# Altium schematic sheet Power.SchDoc
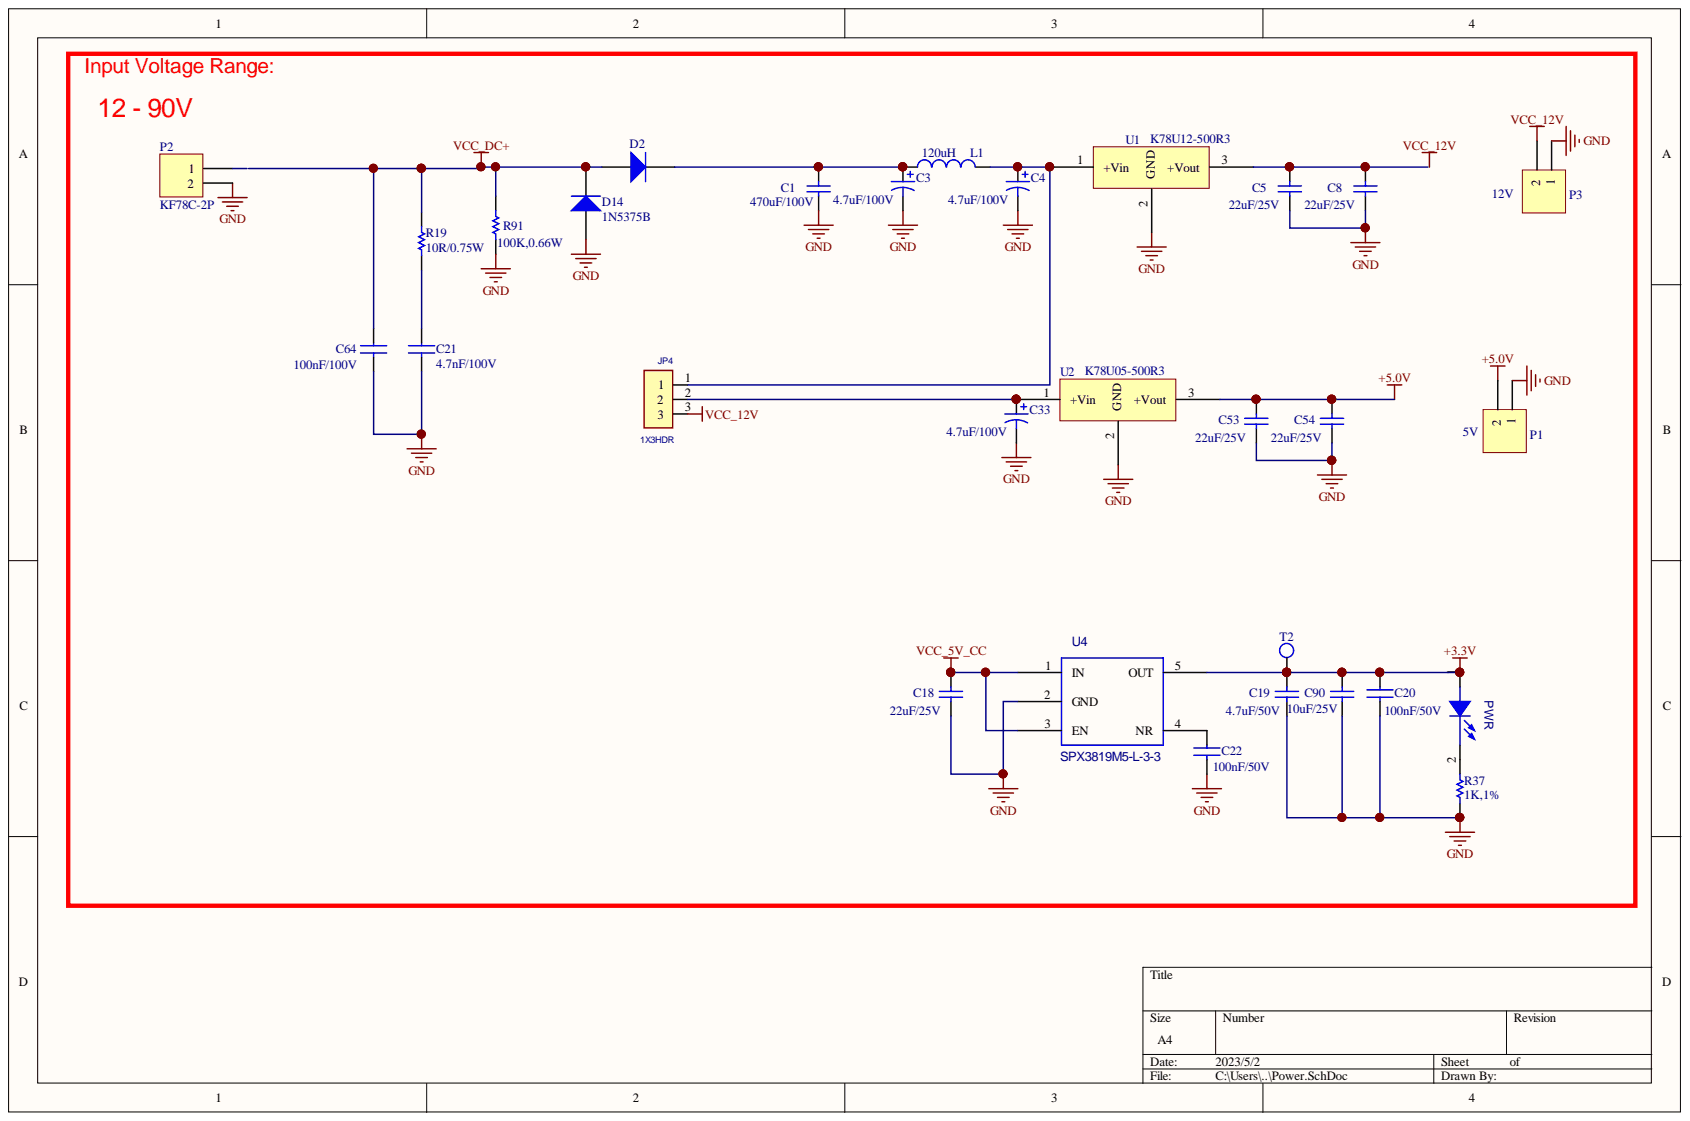
[diagram p0: Power.SchDoc]

## Parts
C1  470uF/100V  [Can_5.0_12.5]
C3  4.7uF/100V  [CASE_D/7343]
C4  4.7uF/100V  [CASE_D/7343]
C5  22uF/25V  [1210]
C8  22uF/25V  [1210]
C18  22uF/25V  [1206]
C19  4.7uF/50V  [1206]
C20  100nF/50V  [0603]
C21  4.7nF/100V  [0603]
C22  100nF/50V  [0603]
C33  4.7uF/100V  [CASE_D/7343]
C53  22uF/25V  [1210]
C54  22uF/25V  [1210]
C64  100nF/100V  [0805]
C90  10uF/25V  [1206]
D2  (no value)  [DO-214AA]
D14  1N5375B  [1N5375B]
JP4  1X3HDR  [0805_3P]
L1  120uH  [L_12mm_SMD]
P1  5V  [HDR2X1_5.0mm]
P2  KF78C-2P  [KF65C-2P]
P3  12V  [HDR2X1_5.0mm]
PWR  Green  [0805_DIODE]  (value not drawn)
R19  10R/0.75W  [1206]
R37  1K,1%  [0603]
R91  100K,0.66W  [1206]
T2  Test_Ring  [1]  (value not drawn)
U1  K78U12-500R3  [K78U12-500R3]
U2  K78U05-500R3  [K78U12-500R3]
U4  SPX3819M5-L-3-3  [SOT-235]

## Nets
+3.3V: C19.2 C20.1 C90.2 PWR.1 T2.1 U4.5(OUT)
+5.0V: C53.2 C54.2 P1.2 U2.3
GND: C1.1 C3.2 C4.2 C5.1 C8.1 C18.1 C19.1 C20.2 C21.2 C22.2 C33.2 C53.1 C54.1 C64.2 C90.1 D14.1 P1.1 P2.2 P3.1 R37.2 R91.2 U1.2 U2.2 U4.2(GND)
VCC_5V_CC: C18.2 U4.1(IN) U4.3(EN)
VCC_12V: JP4.3 P3.2
VCC_DC+: C64.1 D2.1 D14.2 R19.2 R91.1
NetC1_2: C1.2 C3.1 D2.2 L1.1
NetC4_1: C4.1 JP4.1 L1.2 U1.1
NetC5_2: C5.2 C8.2 U1.3
NetC21_1: C21.1 R19.1
NetC22_1: C22.1 U4.4(NR)
NetC33_1: C33.1 JP4.2 U2.1
NetPWR_2: PWR.2 R37.1

Not connected: P2 1

## Library data of the parts
C1 C5 C8 C18 C19 C53 C54 C90 = C1206: Component Kind: Standard; Library Name: useForA104.IntLib
C3 C4 C33 = Cap Pol1: TCJD475M100R0250E; Value: 10uF; Component Kind: Standard; LatestRevisionDate: 17-Jul-2002; LatestRevisionNote: Re-released for DXP Platform.; PackageReference: RB7.6-15; Published: 8-Jun-2000; Publisher: Altium Limited
C20-C22 = Component-CAP: User Parameter 1: foot; Component Kind: Standard; Library Name: AltiumDesigner_Lib-tony.SchLib
C64 = Component-CAP: CGA3E3X7S2A104K080AB; User Parameter 1: foot; Component Kind: Standard; Library Name: AltiumDesigner_Lib-tony.SchLib
D2 D14 = Diode 1N4007: Code_JEDEC: DO-204-AL; Component Kind: Standard; ComponentLink1Description: Manufacturer Link; ComponentLink1URL: http://www.fairchildsemi.com/; ComponentLink2Description: Datasheet; ComponentLink2URL: http://www.fairchildsemi.com/ds/1N%2F1N4001.pdf; DatasheetDocument: 1998; LatestRevisionDate: 17-Jul-2002; LatestRevisionNote: Re-released for DXP Platform.; Library Name: Miscellaneous Devices.IntLib; PackageDocument: Aug-1999; PackageReference: DO-41; Published: 8-Jun-2000; Publisher: Altium Limited
JP4 = 1X3HDR: Part Number: 320-00019; Vendor: Harwin; Vendor PN: M22-2510305; Component Kind: Standard; Distributor: digikey; Distributor Part Number: 952-1312-ND; Part Type: CONN
L1 = Inductor: SRR1206-121YL; Code_IEC: 1005; Value: 330uH; Code_JEITA: 0402; Component Kind: Standard; LatestRevisionDate: 17-Jul-2002; LatestRevisionNote: Re-released for DXP Platform.; Library Name: Miscellaneous Devices.IntLib; PackageReference: 0402-A; Published: 8-Jun-2000; Publisher: Altium Limited
P1-P3 = Header 2: Header, 2-Pin; Component Kind: Standard; LatestRevisionDate: 17-Jul-2002; LatestRevisionNote: Re-released for DXP Platform.; Library Name: Miscellaneous Connectors.IntLib; Signal Integrity: Connector; Publisher: Altium Limited
PWR = LED: Part Number: D3-0070074; FPLIST: D3-SM_D_0805_ALT,D3-SM_D_0805; Rating: Vf=2.1V; Voltage: 20mA; Component Height: 1.10MM; Component Kind: Standard
R19 R91 = Res1: Value: 2.2K; Component Kind: Standard; LatestRevisionDate: 17-Jul-2002; LatestRevisionNote: Re-released for DXP Platform.; Library Name: Miscellaneous Devices.IntLib; PackageReference: AXIAL-0.3; Published: 8-Jun-2000; Signal Integrity: Res; Simulation: RESISTOR; Publisher: Altium Limited
R37 = Res Semi: Value: 20K; Component Kind: Standard; LatestRevisionDate: 17-Jul-2002; LatestRevisionNote: Re-released for DXP Platform.; Library Name: XDS100V3.SCHLIB; PackageReference: AXIAL-0.5; Published: 8-Jun-2000; Signal Integrity: Res Semi; Simulation: RES; Publisher: Altium Limited
U2 = K78U12-500R3: Component Kind: Standard; Library Name: AltiumDesigner_Lib-syc.SchLib
U4 = TPS7363XXDBVREP: Part Number: D3-0130115; Rating: 400mA; Voltage: 3.3V; Component Height: 1.45MM; Component Kind: Standard
T2 = Test_Ring: Component Kind: Standard; Library Name: AltiumDesigner_Lib-syc.SchLib
U1 = K78U12-500R3: Component Kind: Standard; Library Name: AltiumDesigner_Lib-syc.SchLib
